# Altium schematic sheet 11_Power.SchDoc(11_Power)
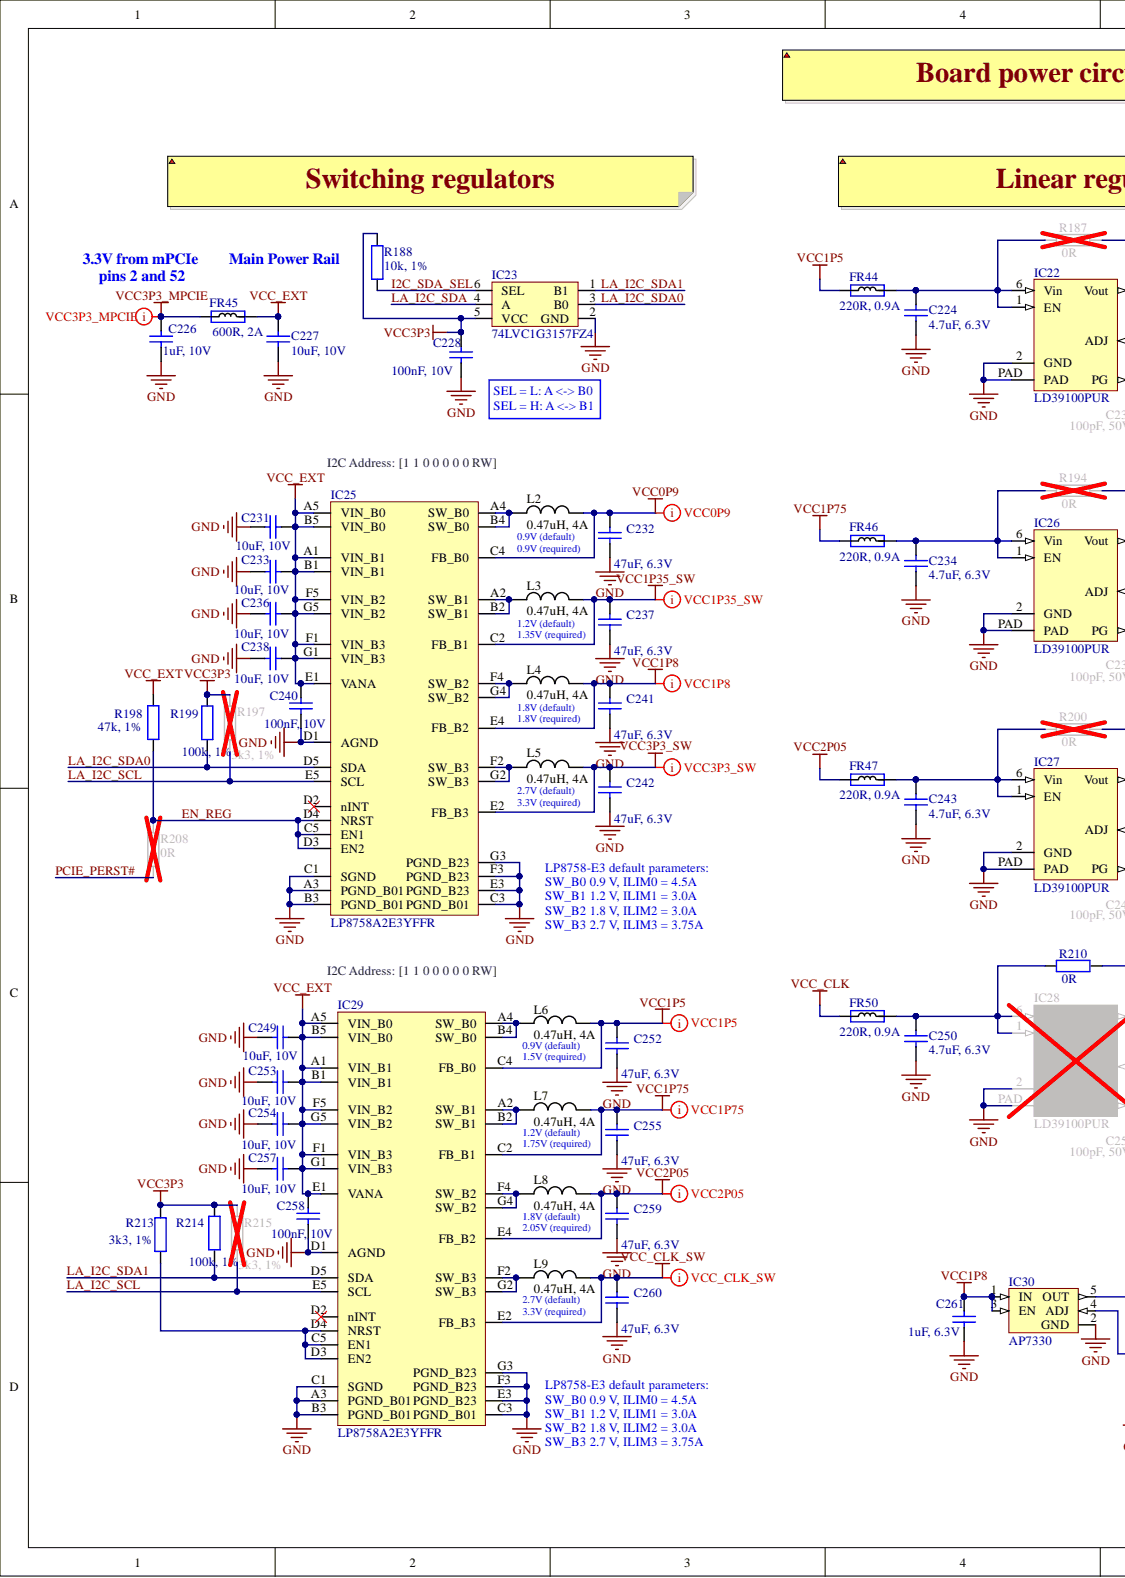
[diagram p0: 11_Power.SchDoc, left]
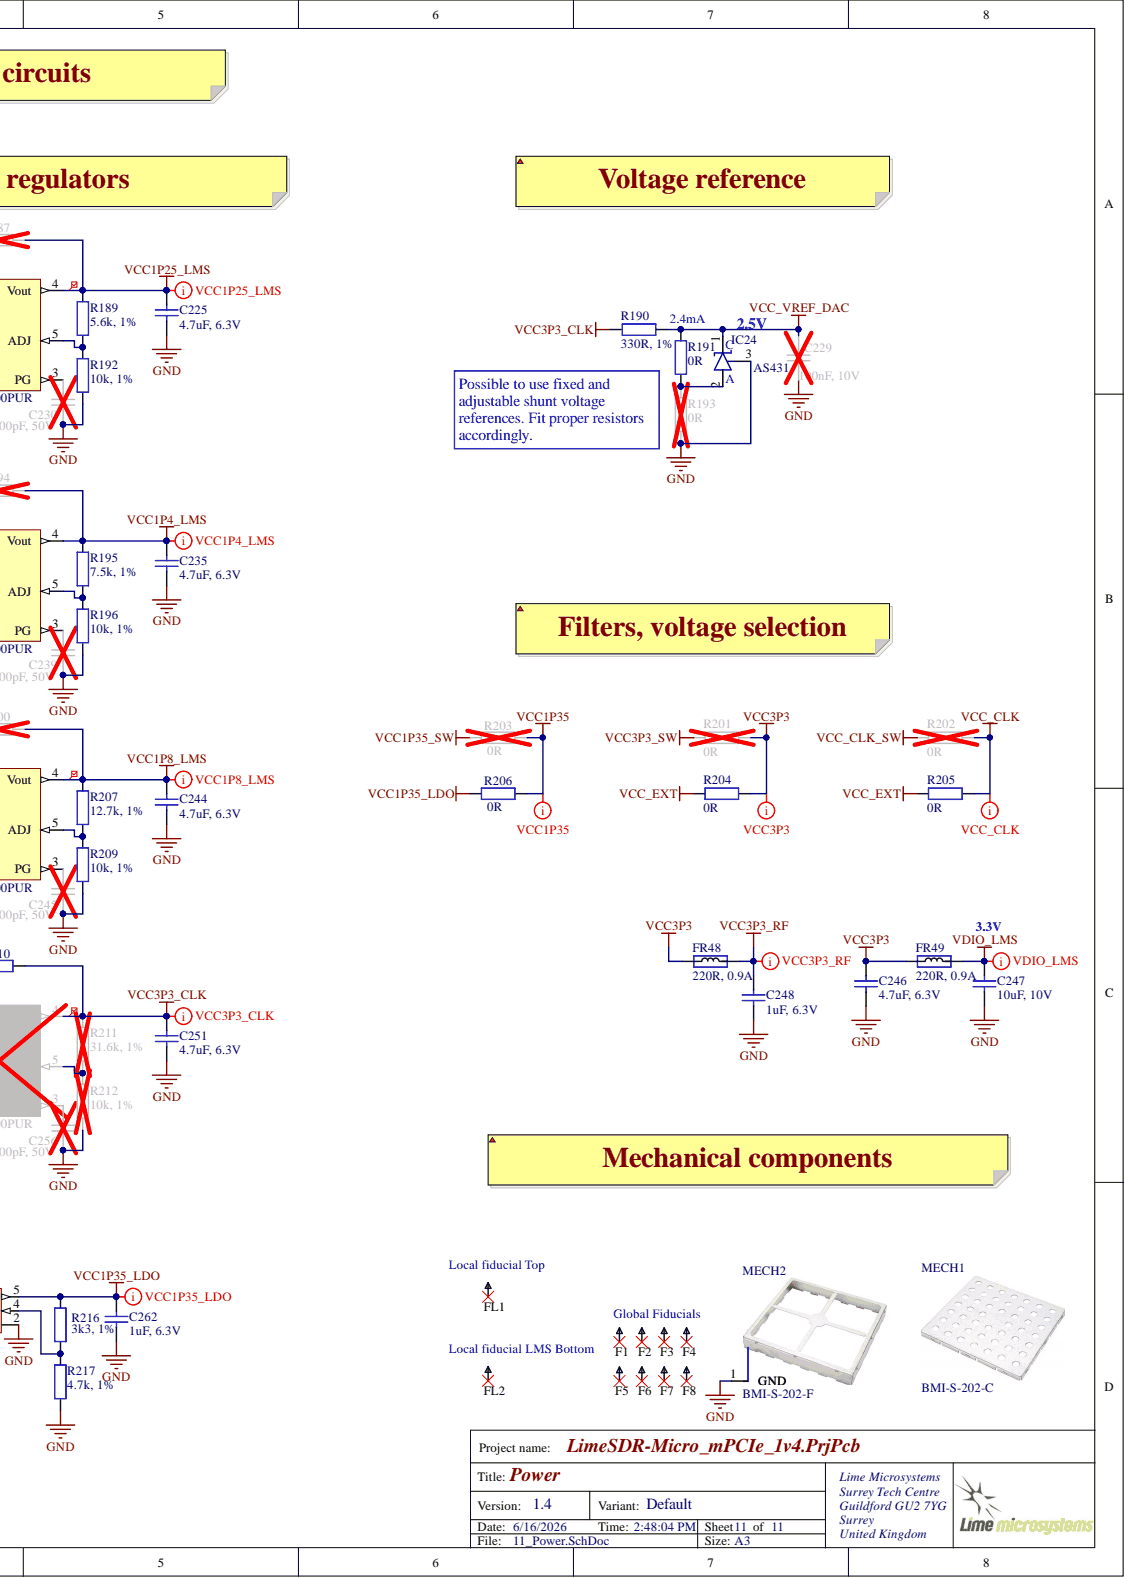
[diagram p1: 11_Power.SchDoc, right]

## Parts
C224  4.7uF, 6.3V  [CAP0402]
C225  4.7uF, 6.3V  [CAP0402]
C226  1uF, 10V  [CAP0402]
C227  10uF, 10V  [CAP0603]
C228  100nF, 10V  Voltage Rating (DC): 10V  [CAP0201]
C229  100nF, 10V  Voltage Rating (DC): 10V  [CAP0201]
C230  100pF, 50V  Voltage Rating (DC): 50V  [CAP0201]
C231  10uF, 10V  Voltage Rating (DC): 10V  [CAP0603]
C232  47uF, 6.3V  Voltage Rating (DC): 6.3V  [CAP0603]
C233  10uF, 10V  Voltage Rating (DC): 10V  [CAP0603]
C234  4.7uF, 6.3V  [CAP0402]
C235  4.7uF, 6.3V  [CAP0402]
C236  10uF, 10V  Voltage Rating (DC): 10V  [CAP0603]
C237  47uF, 6.3V  Voltage Rating (DC): 6.3V  [CAP0603]
C238  10uF, 10V  Voltage Rating (DC): 10V  [CAP0603]
C239  100pF, 50V  Voltage Rating (DC): 50V  [CAP0201]
C240  100nF, 10V  Voltage Rating (DC): 10V  [CAP0201]
C241  47uF, 6.3V  Voltage Rating (DC): 6.3V  [CAP0603]
C242  47uF, 6.3V  Voltage Rating (DC): 6.3V  [CAP0603]
C243  4.7uF, 6.3V  [CAP0402]
C244  4.7uF, 6.3V  [CAP0402]
C245  100pF, 50V  Voltage Rating (DC): 50V  [CAP0201]
C246  4.7uF, 6.3V  [CAP0402]
C247  10uF, 10V  [CAP0603]
C248  1uF, 6.3V  Voltage - Rated: 6.3V  [CAP0201]
C249  10uF, 10V  Voltage Rating (DC): 10V  [CAP0603]
C250  4.7uF, 6.3V  [CAP0402]
C251  4.7uF, 6.3V  [CAP0402]
C252  47uF, 6.3V  Voltage Rating (DC): 6.3V  [CAP0603]
C253  10uF, 10V  Voltage Rating (DC): 10V  [CAP0603]
C254  10uF, 10V  Voltage Rating (DC): 10V  [CAP0603]
C255  47uF, 6.3V  Voltage Rating (DC): 6.3V  [CAP0603]
C256  100pF, 50V  Voltage Rating (DC): 50V  [CAP0201]
C257  10uF, 10V  Voltage Rating (DC): 10V  [CAP0603]
C258  100nF, 10V  Voltage Rating (DC): 10V  [CAP0201]
C259  47uF, 6.3V  Voltage Rating (DC): 6.3V  [CAP0603]
C260  47uF, 6.3V  Voltage Rating (DC): 6.3V  [CAP0603]
C261  1uF, 6.3V  Voltage - Rated: 6.3V  [CAP0201]
C262  1uF, 6.3V  Voltage - Rated: 6.3V  [CAP0201]
F1  Fiducial  [Fiducial]
F2  Fiducial  [Fiducial]
F3  Fiducial  [Fiducial]
F4  Fiducial  [Fiducial]
F5  Fiducial  [Fiducial]
F6  Fiducial  [Fiducial]
F7  Fiducial  [Fiducial]
F8  Fiducial  [Fiducial]
FL1  Fiducial  [Fiducial_local]
FL2  Fiducial  [Fiducial_local]
FR44  220R, 0.9A  [RES0402]
FR45  600R, 2A  Current Rating: 2A  [RES0805]
FR46  220R, 0.9A  [RES0402]
FR47  220R, 0.9A  [RES0402]
FR48  220R, 0.9A  [RES0402]
FR49  220R, 0.9A  [RES0402]
FR50  220R, 0.9A  [RES0402]
IC22  LD39100PUR  [LD39100PUR]
IC23  74LVC1G3157FZ4  [74LVC1G3157FZ4_SPDT_SW_DFN1410-6]
IC24  AS431  [SOT23-3_v3]
IC25  LP8758A2E3YFFR  [LP8758A1E0YFFR_QUAD_DC-DC_35DSBGA]
IC26  LD39100PUR  [LD39100PUR]
IC27  LD39100PUR  [LD39100PUR]
IC28  LD39100PUR  [LD39100PUR]
IC29  LP8758A2E3YFFR  [LP8758A1E0YFFR_QUAD_DC-DC_35DSBGA]
IC30  AP7330  [AP7330-W5-7_SOT25]
L2  0.47uH, 4A  [IND0806]
L3  0.47uH, 4A  [IND0806]
L4  0.47uH, 4A  [IND0806]
L5  0.47uH, 4A  [IND0806]
L6  0.47uH, 4A  [IND0806]
L7  0.47uH, 4A  [IND0806]
L8  0.47uH, 4A  [IND0806]
L9  0.47uH, 4A  [IND0806]
MECH1  BMI-S-202-C  [BMI-S-202-C_RF_SHIELD_COVER]
MECH2  BMI-S-202-F  [BMI-S-202-F_RF_SHIELD_FRAME_v3]
R187  0R  [RES0201]
R188  10k, 1%  Tolerance: 1%  [RES0201]
R189  5.6k, 1%  Tolerance: 1%  [RES0201]
R190  330R, 1%  Tolerance: 1%  [RES0201]
R191  0R  [RES0201]
R192  10k, 1%  Tolerance: 1%  [RES0201]
R193  0R  [RES0201]
R194  0R  [RES0201]
R195  7.5k, 1%  Tolerance: 1%  [RES0201]
R196  10k, 1%  Tolerance: 1%  [RES0201]
R197  3k3, 1%  Tolerance: 1%  [RES0201]
R198  47k, 1%  Tolerance: 1%  [RES0201]
R199  100k, 1%  Tolerance: 1%  [RES0201]
R200  0R  [RES0201]
R201  0R  [RES0402]
R202  0R  [RES0402]
R203  0R  [RES0201]
R204  0R  [RES0402]
R205  0R  [RES0402]
R206  0R  [RES0201]
R207  12.7k, 1%  Tolerance: 1%  [RES0201]
R208  0R  [RES0201]
R209  10k, 1%  Tolerance: 1%  [RES0201]
R210  0R  [RES0201]
R211  31.6k, 1%  Tolerance: 1%  [RES0201]
R212  10k, 1%  Tolerance: 1%  [RES0201]
R213  3k3, 1%  Tolerance: 1%  [RES0201]
R214  100k, 1%  Tolerance: 1%  [RES0201]
R215  3k3, 1%  Tolerance: 1%  [RES0201]
R216  3k3, 1%  Tolerance: 1%  [RES0201]
R217  4.7k, 1%  Tolerance: 1%  [RES0201]

## Nets
GND: C224.1 C225.1 C226.1 C227.1 C228.2 C229.2 C230.1 C231.1 C232.1 C233.1 C234.1 C235.1 C236.1 C237.1 C238.1 C239.1 C240.2 C241.1 C242.1 C243.1 C244.1 C245.1 C246.1 C247.1 C248.2 C249.1 C250.1 C251.1 C252.1 C253.1 C254.1 C255.1 C256.1 C257.1 C258.2 C259.1 C260.1 C261.2 C262.2 IC22.2(GND) IC22.PAD IC23.2(GND) IC24.3 IC25.A3(PGND_B01) IC25.B3(PGND_B01) IC25.C1(SGND) IC25.C3(PGND_B01) IC25.D1(AGND) IC25.E3(PGND_B23) IC25.F3(PGND_B23) IC25.G3(PGND_B23) IC26.2(GND) IC26.PAD IC27.2(GND) IC27.PAD IC28.2(GND) IC28.PAD IC29.A3(PGND_B01) IC29.B3(PGND_B01) IC29.C1(SGND) IC29.C3(PGND_B01) IC29.D1(AGND) IC29.E3(PGND_B23) IC29.F3(PGND_B23) IC29.G3(PGND_B23) IC30.2(GND) MECH2.1 R192.2 R193.2 R196.2 R209.2 R212.2 R217.2
VCC0P9: C232.2 IC25.C4(FB_B0) L2.2
VCC1P4_LMS: C235.2 IC26.4(Vout) R194.2 R195.1
VCC1P5: C252.2 FR44.1 IC29.C4(FB_B0) L6.2
VCC1P8: C241.2 C261.1 IC25.E4(FB_B2) IC30.1(IN) IC30.3(EN) L4.2
VCC1P8_LMS: C244.2 IC27.4(Vout) R200.2 R207.1
VCC1P25_LMS: C225.2 IC22.4(Vout) R187.2 R189.1
VCC1P35: R203.2 R206.2
VCC1P35_LDO: C262.1 IC30.5(OUT) R206.1 R216.1
VCC1P35_SW: C237.2 IC25.C2(FB_B1) L3.2 R203.1
VCC1P75: C255.2 FR46.1 IC29.C2(FB_B1) L7.2
VCC2P05: C259.2 FR47.1 IC29.E4(FB_B2) L8.2
VCC3P3: C228.1 C246.2 FR48.1 FR49.1 IC23.5(VCC) R188.2 R197.1 R199.1 R201.2 R204.2 R213.1 R214.1 R215.1
VCC3P3_CLK: C251.2 IC28.4(Vout) R190.2 R210.2 R211.1
VCC3P3_MPCIE: C226.2 FR45.1
VCC3P3_RF: C248.1 FR48.2
VCC3P3_SW: C242.2 IC25.E2(FB_B3) L5.2 R201.1
VCC_CLK: FR50.1 R202.2 R205.2
VCC_CLK_SW: C260.2 IC29.E2(FB_B3) L9.2 R202.1
VCC_EXT: C227.2 C231.2 C233.2 C236.2 C238.2 C240.1 C249.2 C253.2 C254.2 C257.2 C258.1 FR45.2 IC25.A1(VIN_B1) IC25.A5(VIN_B0) IC25.B1(VIN_B1) IC25.B5(VIN_B0) IC25.E1(VANA) IC25.F1(VIN_B3) IC25.F5(VIN_B2) IC25.G1(VIN_B3) IC25.G5(VIN_B2) IC29.A1(VIN_B1) IC29.A5(VIN_B0) IC29.B1(VIN_B1) IC29.B5(VIN_B0) IC29.E1(VANA) IC29.F1(VIN_B3) IC29.F5(VIN_B2) IC29.G1(VIN_B3) IC29.G5(VIN_B2) R198.1 R204.1 R205.1
VCC_VREF_DAC: C229.1 IC24.1 R190.1 R191.1
VDIO_LMS: C247.2 FR49.2
EN_REG: IC25.C5(EN1) IC25.D3(EN2) IC25.D4(NRST) R198.2 R208.2
I2C_SDA_SEL: IC23.6(SEL) R188.1
LA_I2C_SCL: IC25.E5(SCL) IC29.E5(SCL) R197.2 R215.2
LA_I2C_SDA: IC23.3(B0) IC23.4(A) IC25.D5(SDA) R199.2  [also labelled LA_I2C_SDA0]
LA_I2C_SDA1: IC23.1(B1) IC29.D5(SDA) R214.2
PCIE_PERST#: R208.1
NetC224_2: C224.2 FR44.2 IC22.1(EN) IC22.6(Vin) R187.1
NetC230_2: C230.2 IC22.3(PG)
NetC234_2: C234.2 FR46.2 IC26.1(EN) IC26.6(Vin) R194.1
NetC239_2: C239.2 IC26.3(PG)
NetC243_2: C243.2 FR47.2 IC27.1(EN) IC27.6(Vin) R200.1
NetC245_2: C245.2 IC27.3(PG)
NetC250_2: C250.2 FR50.2 IC28.1(EN) IC28.6(Vin) R210.1
NetC256_2: C256.2 IC28.3(PG)
NetIC22_5: IC22.5(ADJ) R189.2 R192.1
NetIC24_2: IC24.2 R191.2 R193.1
NetIC25_A2: IC25.A2(SW_B1) IC25.B2(SW_B1) L3.1
NetIC25_A4: IC25.A4(SW_B0) IC25.B4(SW_B0) L2.1
NetIC25_F2: IC25.F2(SW_B3) IC25.G2(SW_B3) L5.1
NetIC25_F4: IC25.F4(SW_B2) IC25.G4(SW_B2) L4.1
NetIC26_5: IC26.5(ADJ) R195.2 R196.1
NetIC27_5: IC27.5(ADJ) R207.2 R209.1
NetIC28_5: IC28.5(ADJ) R211.2 R212.1
NetIC29_A2: IC29.A2(SW_B1) IC29.B2(SW_B1) L7.1
NetIC29_A4: IC29.A4(SW_B0) IC29.B4(SW_B0) L6.1
NetIC29_C5: IC29.C5(EN1) IC29.D3(EN2) IC29.D4(NRST) R213.2
NetIC29_F2: IC29.F2(SW_B3) IC29.G2(SW_B3) L9.1
NetIC29_F4: IC29.F4(SW_B2) IC29.G4(SW_B2) L8.1
NetIC30_4: IC30.4(ADJ) R216.2 R217.1

Not connected: F1 1; F2 1; F3 1; F4 1; F5 1; F6 1; F7 1; F8 1; FL1 1; FL2 1; IC25 D2(nINT); IC29 D2(nINT)
